# Altium schematic sheet 07-PERIPHERIQUES.SchDoc(07-PERIPHERIQUES)
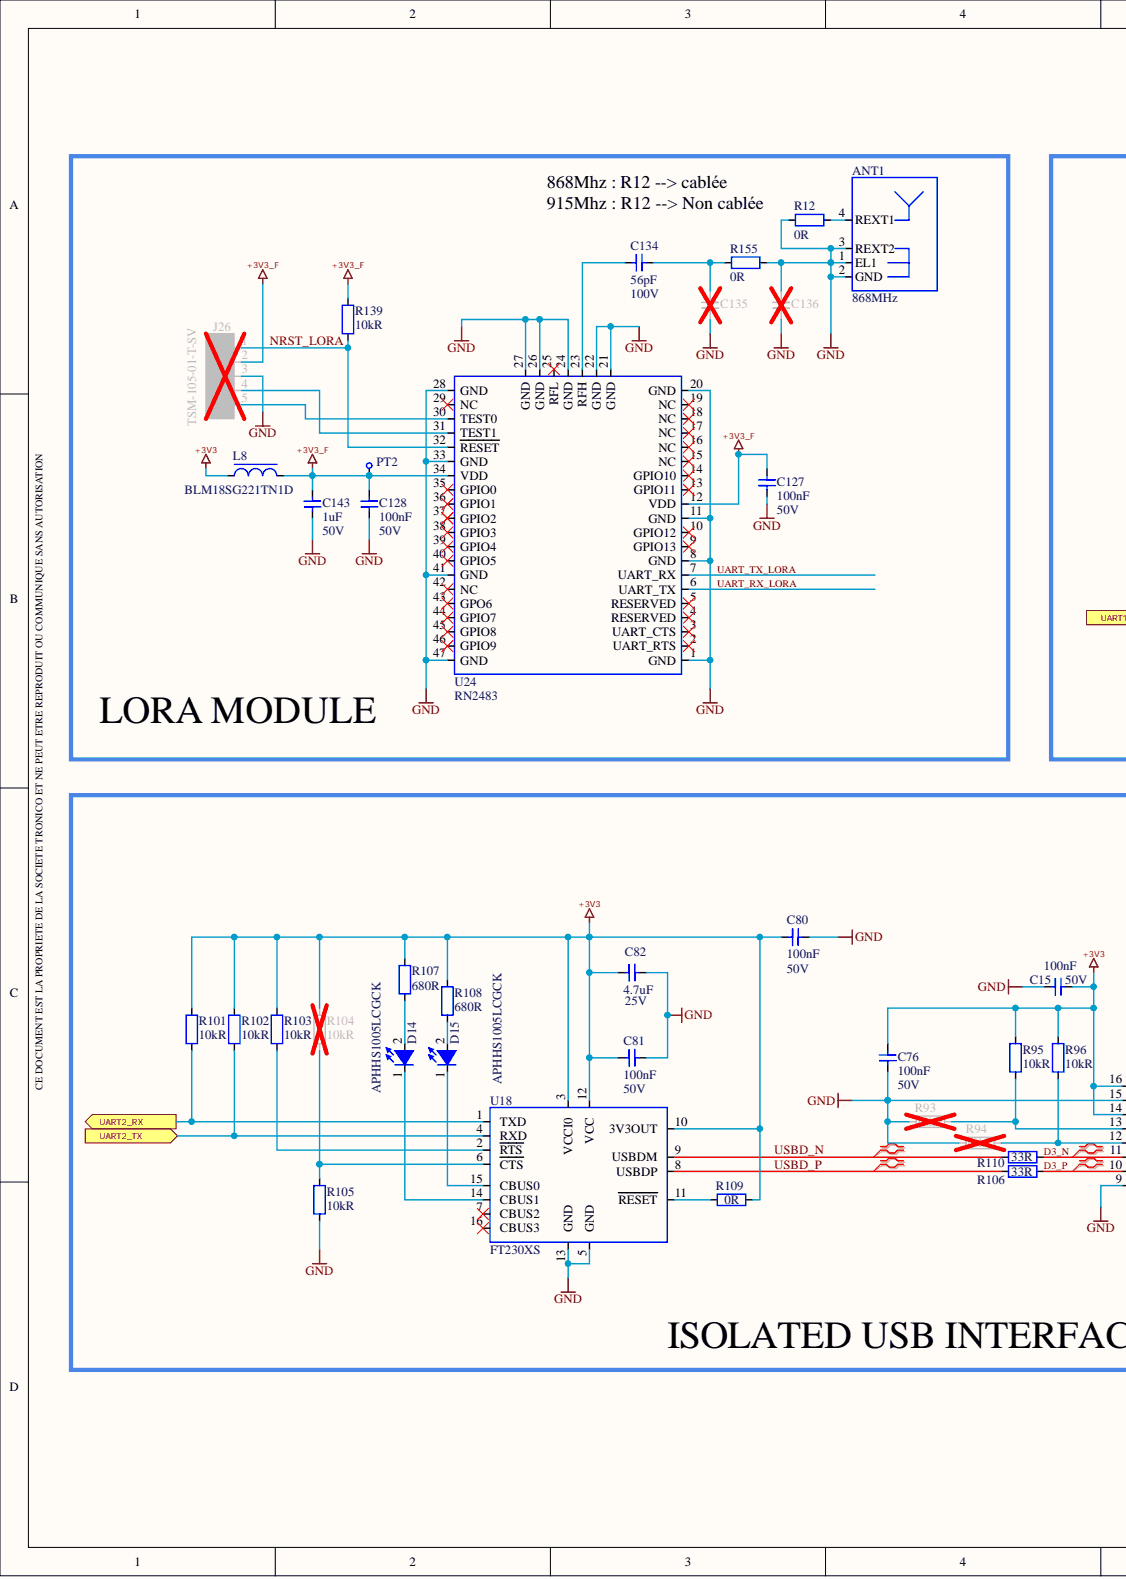
[diagram p0: 07-PERIPHERIQUES.SchDoc, left]
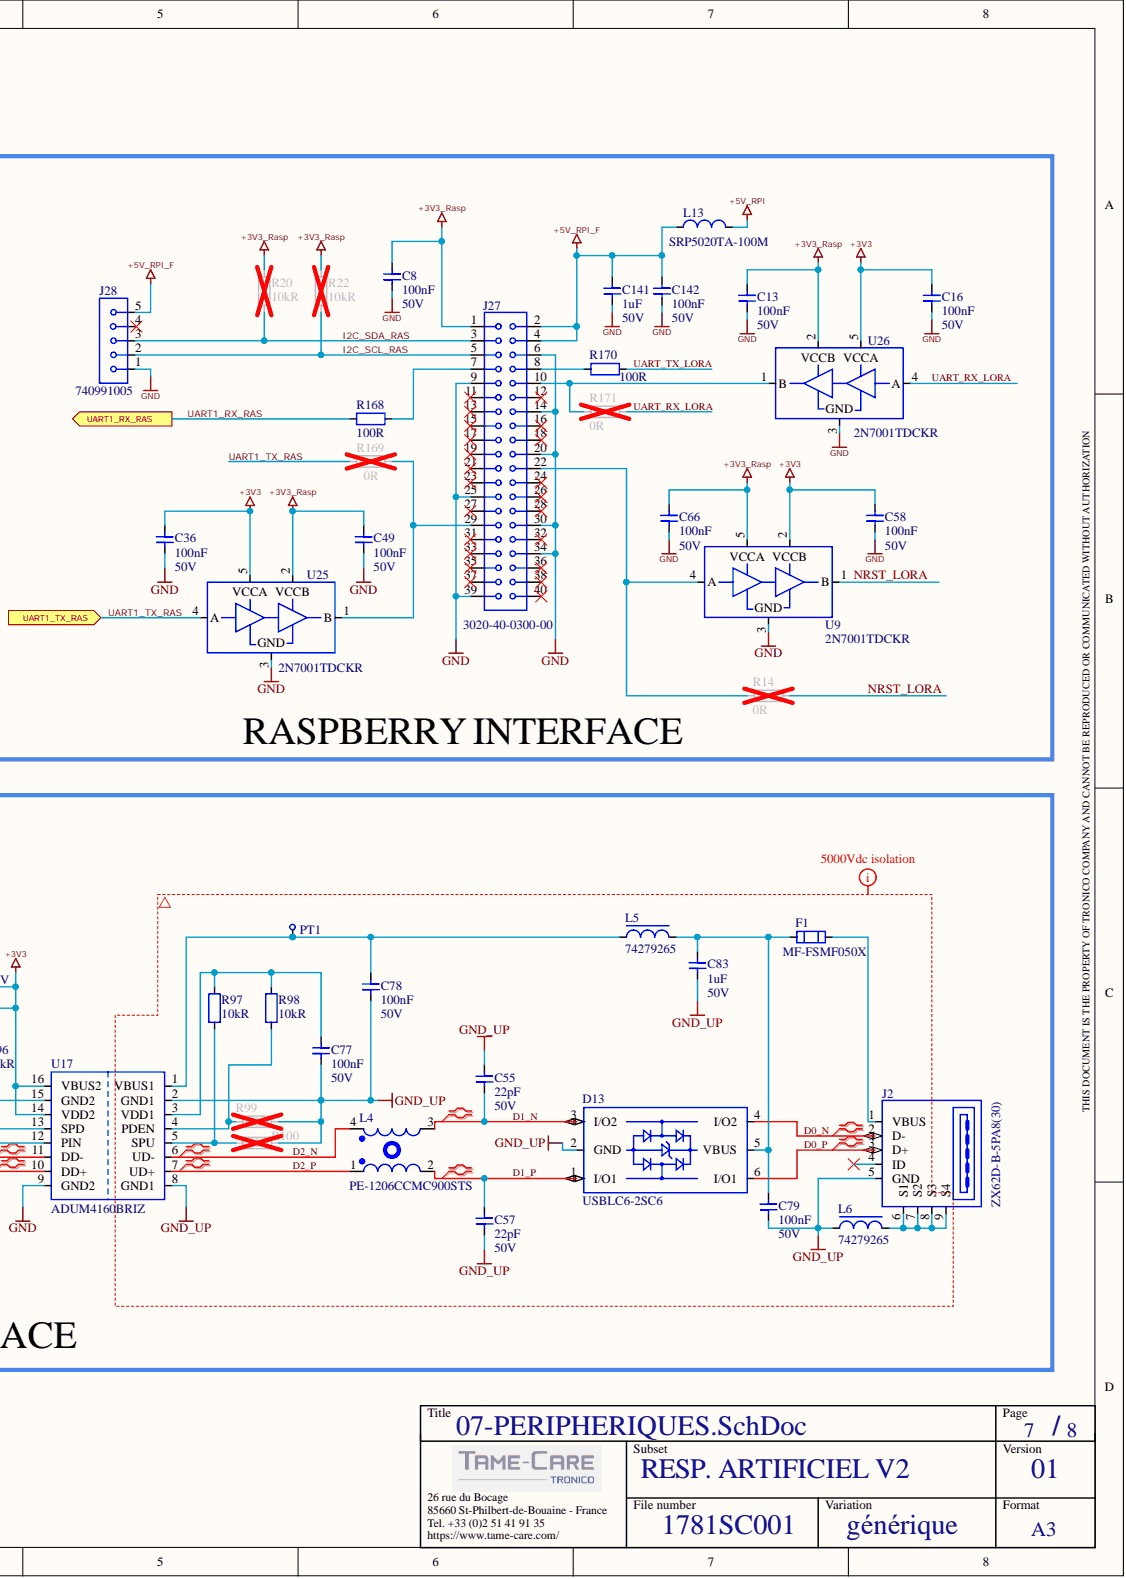
[diagram p1: 07-PERIPHERIQUES.SchDoc, right]

## Parts
ANT1  868MHz  [ANT_LoRa_SX217x_LSDR4RF_V02A]  (no BOM)
C8  100nF  Parameter 1: 50V  [CAPC_0603X094_T]
C13  100nF  Parameter 1: 50V  [CAPC_0603X094_T]
C15  100nF  Parameter 1: 50V  [CAPC_0603X094_T]
C16  100nF  Parameter 1: 50V  [CAPC_0603X094_T]
C36  100nF  [CAPC_0603X094_T]
C49  100nF  Parameter 1: 50V  [CAPC_0603X094_T]
C55  22pF  Parameter 1: 50V  [CAPC_0603X090_T]
C57  22pF  Parameter 1: 50V  [CAPC_0603X090_T]
C58  100nF  Parameter 1: 50V  [CAPC_0603X094_T]
C66  100nF  [CAPC_0603X094_T]
C76  100nF  Parameter 1: 50V  [CAPC_0603X094_T]
C77  100nF  Parameter 1: 50V  [CAPC_0603X094_T]
C78  100nF  Parameter 1: 50V  [CAPC_0603X094_T]
C79  100nF  Parameter 1: 50V  [CAPC_0603X094_T]
C80  100nF  Parameter 1: 50V  [CAPC_0603X094_T]
C81  100nF  [CAPC_0603X094_T]
C82  4.7uF  CHAMP6: 25V  [CAPC_0603X080_T]
C83  1uF  Parameter 1: 50V  [CAPC_0603X080_T]
C127  100nF  Parameter 1: 50V  [CAPC_0603X094_T]
C128  100nF  Parameter 1: 50V  [CAPC_0603X094_T]
C134  56pF  Parameter 1: 100V  [CAPC_0402X050_T]
C135  27pF  [CAPC_0402X050_T]  (value not drawn)
C136  27pF  [CAPC_0402X050_T]  (value not drawn)
C141  1uF  Parameter 1: 50V  [CAPC_0603X080_T]
C142  100nF  Parameter 1: 50V  [CAPC_0603X094_T]
C143  1uF  Parameter 1: 50V  [CAPC_0603X080_T]
D13  USBLC6-2SC6  [SOT23-6_1.6X2.9_1.45_B]
D14  APHHS1005LCGCK  [LEDC_0402x050_GREEN_B]
D15  APHHS1005LCGCK  [LEDC_0402x050_GREEN_B]
F1  MF-FSMF050X  [MF-FSMF050X]
J2  ZX62D-B-5PA8(30)  [CNT_HIROSE_ZX62D_B_5P8_B]
J26  TSM-105-01-T-SV  [CNT_SAMTEC_TSM-105-01-X-SV_B]
J27  3020-40-0300-00  [CNT_CNC_3020-40-0300-00_B]
J28  740991005  [CNT_MOLEX_74099-1005_B]
L4  PE-1206CCMC900STS  [INDC_CCMC-1206_B]
L5  74279265  [IND_0603X080_T]
L6  74279265  [IND_0603X080_T]
L8  BLM18SG221TN1D  [IND_0603X094_T]
L13  SRP5020TA-100M  [INDC_SRP5020T_B]
PT1  PTC3  [PT_0.8-1.5MM]  (value not drawn)  (no BOM)
PT2  PT_0.4  [PT_0.4-1.0mm]  (value not drawn)  (no BOM)
R12  0R  [RESC_0603X045_T]
R14  0R  [RESC_0603X045_T]
R20  10kR  [RESC_0603X045_T]
R22  10kR  [RESC_0603X045_T]
R93  10kR  [RESC_0603X045_T]
R94  10kR  [RESC_0603X045_T]
R95  10kR  [RESC_0603X045_T]
R96  10kR  [RESC_0603X045_T]
R97  10kR  [RESC_0603X045_T]
R98  10kR  [RESC_0603X045_T]
R99  10kR  [RESC_0603X045_T]
R100  10kR  [RESC_0603X045_T]
R101  10kR  [RESC_0603X045_T]
R102  10kR  [RESC_0603X045_T]
R103  10kR  [RESC_0603X045_T]
R104  10kR  [RESC_0603X045_T]
R105  10kR  [RESC_0603X045_T]
R106  33R  [RESC_0603X045_T]
R107  680R  [RESC_0603X045_T]
R108  680R  [RESC_0603X045_T]
R109  0R  [RESC_0603X045_T]
R110  33R  [RESC_0603X045_T]
R139  10kR  [RESC_0603X045_T]
R155  0R  [RESC_0402X035_T]
R168  100R  [RESC_0603X045_T]
R169  0R  [RESC_0603X045_T]
R170  100R  [RESC_0603X045_T]
R171  0R  [RESC_0603X045_T]
U9  2N7001TDCKR  [SC70-5_B]
U17  ADUM4160BRIZ  [SO16W_1.27_12.85X7.6X2.64_B]
U18  FT230XS  [SSOP16_0.635_3.9X4.9X1.8_B]
U24  RN2483  [MICROCHIP_LORA_RN2483_B]
U25  2N7001TDCKR  [SC70-5_B]
U26  2N7001TDCKR  [SC70-5_B]

## Ports
UART1_RX_RAS, UART1_TX_RAS, UART2_RX, UART2_TX

## Nets
+3V3: C15.2 C16.2 C36.2 C58.2 C76.2 C80.1 C81.1 C82.2 L8.1 R95.2 R96.2 R101.1 R102.1 R103.1 R104.1 R107.1 R108.1 R109.1 U9.2 U17.14(VDD2) U17.16(VBUS2) U18.3(VCCI0) U18.10(3V3OUT) U18.12(VCC) U25.5 U26.5
+3V3_F: C127.2 C128.2 C143.2 J26.2 L8.2 PT2.1 R139.1 U24.12(VDD) U24.34(VDD)
+3V3_Rasp: C8.2 C13.2 C49.2 C66.2 J27.1 R20.1 R22.1 U9.5 U25.2 U26.2
+5V_RPI: L13.2
+5V_RPI_F: C141.2 C142.2 J27.2 J27.4 J28.5 L13.1
GND: ANT1.1(EL1) ANT1.2(GND) ANT1.3(REXT2) C8.1 C13.1 C15.1 C16.1 C36.1 C49.1 C58.1 C66.1 C76.1 C80.2 C81.2 C82.1 C127.1 C128.1 C135.1 C136.1 C136.2 C141.1 C142.1 C143.1 J26.3 J27.6 J27.9 J27.14 J27.20 J27.25 J27.30 J27.34 J27.39 J28.1 R12.1 R93.2 R94.2 R105.2 R155.1 U9.3 U17.9(GND2) U17.15(GND2) U18.5(GND) U18.13(GND) U24.1(GND) U24.8(GND) U24.11(GND) U24.20(GND) U24.21(GND) U24.22(GND) U24.24(GND) U24.26(GND) U24.27(GND) U24.28(GND) U24.33(GND) U24.41(GND) U24.47(GND) U25.3 U26.3
GND_UP: C55.2 C57.1 C77.1 C78.1 C79.1 C83.1 D13.2(GND) J2.5(GND) L6.1 R99.1 R100.1 U17.2(GND1) U17.8(GND1)
D0_N: D13.4(I/O2) J2.2(D-)
D0_P: D13.6(I/O1) J2.3(D+)
D1_N: C55.1 D13.3(I/O2) L4.3
D1_P: C57.2 D13.1(I/O1) L4.2
D2_N: L4.4 U17.6(UD-)
D2_P: L4.1 U17.7(UD+)
D3_N: R110.1 U17.11(DD-)
D3_P: R106.1 U17.10(DD+)
I2C_SCL_RAS: J27.5 J28.2 R22.2
I2C_SDA_RAS: J27.3 J28.3 R20.2
NRST_LORA: J26.1 R14.1 R139.2 U9.1(B) U24.32(RESET)
UART1_RX_RAS: R168.2 ; port UART1_RX_RAS
UART1_TX_RAS: R169.2 U25.4(A) ; port UART1_TX_RAS
UART2_RX: R101.2 U18.1(TXD) ; port UART2_RX
UART2_TX: R102.2 U18.4(RXD) ; port UART2_TX
UART_RX_LORA: R171.1 U24.6(UART_TX) U26.4(A)
UART_TX_LORA: R170.1 U24.7(UART_RX)
USBD_N: R110.2 U18.9(USBDM)
USBD_P: R106.2 U18.8(USBDP)
NetANT1_4: ANT1.4(REXT1) R12.2
NetC77_2: C77.2 R97.1 R98.1 U17.3(VDD1)
NetC78_2: C78.2 L5.1 PT1.1 U17.1(VBUS1)
NetC79_2: C79.2 C83.2 D13.5(VBUS) F1.2 L5.2
NetC134_1: C134.1 U24.23(RFH)
NetC134_2: C134.2 C135.2 R155.2
NetD14_1: D14.1 U18.14(CBUS1)
NetD14_2: D14.2 R107.2
NetD15_1: D15.1 U18.15(CBUS0)
NetD15_2: D15.2 R108.2
NetF1_1: F1.1 J2.1(VBUS)
NetJ2_6: J2.6(S1) J2.7(S2) J2.8(S3) J2.9(S4) L6.2
NetJ26_4: J26.4 U24.31(TEST1)
NetJ26_5: J26.5 U24.30(TEST0)
NetJ27_7: J27.7 R168.1
NetJ27_8: J27.8 R170.2
NetJ27_10: J27.10 R171.2 U26.1(B)
NetJ27_22: J27.22 R14.2 U9.4(A)
NetJ27_29: J27.29 R169.1 U25.1(B)
NetR93_1: R93.1 R95.1 U17.13(SPD)
NetR94_1: R94.1 R96.1 U17.12(PIN)
NetR97_2: R97.2 R100.2 U17.5(SPU)
NetR98_2: R98.2 R99.2 U17.4(PDEN)
NetR103_2: R103.2 U18.2(RTS)
NetR104_2: R104.2 R105.1 U18.6(CTS)
NetR109_2: R109.2 U18.11(RESET)
N00341:

Not connected: J2 4(ID); J27 11 12 13 15 16 17 18 19 21 23 24 26 27 28 31 32 33 35 36 37 38 40; J28 4; U18 7(CBUS2) 16(CBUS3); U24 2(UART_RTS) 3(UART_CTS) 4(RESERVED) 5(RESERVED) 9(GPIO13) 10(GPIO12) 13(GPIO11) 14(GPIO10) 15(NC) 16(NC) 17(NC) 18(NC) 19(NC) 25(RFL) 29(NC) 35(GPIO0) 36(GPIO1) 37(GPIO2) 38(GPIO3) 39(GPIO4) 40(GPIO5) 42(NC) 43(GPO6) 44(GPIO7) 45(GPIO8) 46(GPIO9)

## Library data of the parts
ANT1 = 4BU00008: ANTENNE PCB 16x50mm 868MHz; REV_NOTE: MaJ Champs Librairies; REV_AUTHOR: llannuzel; REF_FAB: LORA_SX127x_LSDR4RF_V01A; QUALIF: None; LEVEL: 1; FAMILLE: INDUCTIFS; FAB: TRONICO; Database Table Name: v_bt_all; SS_FAMILLE: ANTENNE; Simulation: INDUCTOR; Signal Integrity: Antenne; REV_DATE: 02/05/2020 23:18:44; ID_RnD: 4BU00008; HelpURL: http://bt_rnd/ds/04_inductif/SEMTECH/SX127x_LSDR4RF_V01A.pdf; CHAMP5: 868MHz; CHAMP2: 16x50mm; CHAMP1: PCB; ACTIF: ACTIF; Library Name: SVNDatabase_BaseTechnique.SVNDbLib
C8 C13 C15 C16 C36 C49 C58 C66 C76-C81 C127 C128 C142 = 23U00036: COND_CERAM CMS 0603 X7R 100nF 50V 10%; REV_NOTE: Maj Champs Techniques; REV_AUTHOR: nlelievre; REF_FAB: 06035C104KAT2A; LEVEL: 1; FAMILLE: CAPACITIFS; FAB: AVX; Database Table Name: v_bt_all; CHAMP9: -55°C/+125°C; CHAMP2: 0603; SS_FAMILLE: COND_CERAM; Simulation: CAP; Signal Integrity: Cap; REV_DATE: 16/10/2019 13:20:57; Library Name: SVNDatabase_BaseTechnique.SVNDbLib; ID_RnD: 23U00036; HelpURL: http://Bt_rnd/ds/02_capacitif/AVX/AVX_cx7r.pdf; ComponentLink2Description: Manufacturer Website; ComponentLink1Description: Manufacturer Datasheet; Component Kind: Standard; CHAMP7: 10%; CHAMP6: 50V; CHAMP5: 100nF; CHAMP3: X7R; CHAMP1: CMS; ACTIF: ACTIF
  C36 C66 C81: Parameter 1: 50V
C55 C57 = 23U00046: COND_CERAM CMS 0603 C0G 22pF 50V 10%; REV_NOTE: MaJ Champs Techniques; REV_AUTHOR: lclavier; REF_FAB: C0603C220K5GACTU; LEVEL: 1; FAMILLE: CAPACITIFS; FAB: KEMET; Database Table Name: v_bt_all; CHAMP9: -55°C/+125°C; CHAMP2: 0603; SS_FAMILLE: COND_CERAM; Simulation: CAP; Signal Integrity: Cap; REV_DATE: 02/05/2020 13:19:47; Library Name: SVNDatabase_BaseTechnique.SVNDbLib; ID_RnD: 23U00046; HelpURL: http://Bt_rnd/ds/02_capacitif/AVX/AVX_cx7r.pdf; ComponentLink3URL: None; ComponentLink3Description: Qualification information; ComponentLink2URL: http://www.avx.com; ComponentLink2Description: Manufacturer Website; ComponentLink1URL: http://www.avx.com/docs/Catalogs/cx7r.pdf; ComponentLink1Description: Manufacturer Datasheet; Component Kind: Standard; CHAMP7: 10%; CHAMP6: 50V; CHAMP5: 22pF; CHAMP3: C0G; CHAMP1: CMS; ACTIF: ACTIF
C82 = 23U00282: COND_CERAM CMS 0603 X5R  4.7uF 25V 10%; Valide / Non Valide: OK; REV_NOTE: MaJ Champs Librairies; REV_AUTHOR: llannuzel; REF_FAB: GRM188R61E475KE15D; QUALIF: None; LEVEL: 1; FAMILLE: CAPACITIFS; FAB: MURATA; Database Table Name: v_bt_all; CHAMP9: -55°C/+85°C; CHAMP2: 0603; SS_FAMILLE: COND_CERAM; Simulation: CAP; Signal Integrity: Cap; REV_DATE: 28/05/2018 09:39:46; ID_RnD: 23U00282; HelpURL: http://bt_rnd/ds/02_capacitif/murata/GRM18_series.pdf; ComponentLink2Description: Manufacturer Website; ComponentLink1Description: Manufacturer Datasheet; Component Kind: Standard; CHAMP7: 10%; CHAMP5: 4.7uF; CHAMP3: X5R; CHAMP1: CMS; ACTIF: ACTIF; Library Name: SVNDatabase_BaseTechnique.SVNDbLib
C83 C141 C143 = 23U00347: COND_CERAM CMS 0603 X5R 1uF 50V 10%; REV_NOTE: Maj Champs Techniques; REV_AUTHOR: nlelievre; REF_FAB: CGA3E3X5R1H105K080AB; LEVEL: 1; FAMILLE: CAPACITIFS; FAB: TDK; Database Table Name: v_bt_all; CHAMP9: -55°C/+85°C; CHAMP2: 0603; SS_FAMILLE: COND_CERAM; Simulation: CAP; Signal Integrity: Cap; REV_DATE: 16/10/2019 13:35:17; Library Name: SVNDatabase_BaseTechnique.SVNDbLib; ID_RnD: 23U00347; HelpURL: http://bt_rnd/ds/02_capacitif/TDK/mlccspec_automotive_general_midvoltage_en.pdf; ComponentLink2Description: Manufacturer Website; ComponentLink1Description: Manufacturer Datasheet; Component Kind: Standard; CHAMP7: 10%; CHAMP6: 50V; CHAMP5: 1uF; CHAMP3: X5R; CHAMP1: CMS; ACTIF: ACTIF
C134 = 23U00494: COND_CERAM CMS 0402 COG HF 56pF 100V 1%; REV_NOTE: Migration vers t_bt; REV_AUTHOR: lclavier; REF_FAB: CBR04C560F1GAC; LEVEL: 1; FAMILLE: CAPACITIFS; FAB: KEMET; Database Table Name: v_bt_all; CHAMP9: -55°C/+125°C; CHAMP2: 0402; SS_FAMILLE: COND_CERAM; Simulation: CAP; Signal Integrity: Cap; REV_DATE: 07/05/2020 11:53:31; ID_RnD: 23U00494; HelpURL: http://bt_rnd/ds/02_capacitif/KEMET/KEM_C1030_CBR_SMD.pdf; ComponentLink2Description: Manufacturer Website; ComponentLink1Description: Manufacturer Datasheet; Component Kind: Standard; CHAMP7: 1%; CHAMP6: 100V; CHAMP5: 56pF; CHAMP4: HF; CHAMP3: COG; CHAMP1: CMS; ACTIF: ACTIF; Library Name: SVNDatabase_BaseTechnique.SVNDbLib
C135 C136 = 23U00333: COND_CERAM CMS 0402 C0G 27pF 50V 1%; REV_NOTE: Maj Champs Techniques; REV_AUTHOR: nlelievre; REF_FAB: GCM1555C1H270FA16D; LEVEL: 1; FAMILLE: CAPACITIFS; FAB: MURATA; Database Table Name: v_bt_all; CHAMP9: -55°C/+125°C; CHAMP2: 0402; SS_FAMILLE: COND_CERAM; Simulation: CAP; Signal Integrity: Cap; REV_DATE: 16/10/2019 13:35:03; Parameter 1: 50V; Library Name: SVNDatabase_BaseTechnique.SVNDbLib; ID_RnD: 23U00333; HelpURL: http://BT_RND/ds/02_capacitif/murata/GCM1555C1H270FA16.pdf; ComponentLink2Description: Manufacturer Website; ComponentLink1URL: https://psearch.en.murata.com/capacitor/product/GCM1555C1H270FA16%23.html; ComponentLink1Description: Manufacturer Website; Component Kind: Standard; CHAMP7: 1%; CHAMP6: 50V; CHAMP5: 27pF; CHAMP3: C0G; CHAMP1: CMS; ACTIF: ACTIF
D13 = 38U00032: DIODE_ESD CMS SOT23-6L UNI 15kV  DUAL -40°C/+125°C -55°C/+150°C; REV_NOTE: MàJ footprint_path et footprint_ref; REV_AUTHOR: llannuzel; QUALIF: None; LEVEL: 1; FAMILLE: DIODES; FAB: ST MICROELECTRONICS; Database Table Name: v_bt_all; SS_FAMILLE: DIODE_ESD; REV_DATE: 13/12/2019 10:05:20; REF_FAB: USBLC6-2SC6; ID_RnD: 38U00032; HelpURL: http://bt_rnd/ds/03_diode/st/USBLC6_2.pdf; ComponentLink2Description: Manufacturer Website; ComponentLink1URL: https://www.st.com/content/ccc/resource/technical/document/datasheet/06/1d/48/9c/6c/20/4a/b2/CD00050750.pdf/files/CD00050750.pdf/jcr:content/translations/en.CD00050750.pdf; ComponentLink1Description: Manufacturer Datasheet; Component Kind: Standard; CHAMP10: -55°C/+150°C; CHAMP9: -40°C/+125°C; CHAMP8: DUAL; CHAMP5: 15kV; CHAMP4: UNI; CHAMP2: SOT23-6L; CHAMP1: CMS; ACTIF: ACTIF; Library Name: SVNDatabase_BaseTechnique.SVNDbLib
D14 D15 = E1U00022: DIODE_LED VERTE CMS 0402 574nm 3.5mcd 1.9V; REV_NOTE: MaJ Champs URL; REV_AUTHOR: lclavier; QUALIF: None; LEVEL: 1; FAMILLE: OPTOELECTRONIQUE; FAB: KINGBRIGHT; Database Table Name: v_bt_all; CHAMP10: -40°C/+85°C; CHAMP8: 2mA; CHAMP4: 0402; [ Valide ]: OK; SS_FAMILLE: DIODE_LED; REV_DATE: 15/02/2019 16:02:32; REF_FAB: APHHS1005LCGCK; ID_RnD: E1U00022; HelpURL: http://bt_rnd/ds/15_opto/kingbright/APHHS1005LCGCK.pdf; ComponentLink2Description: Manufacturer Website; ComponentLink1Description: Manufacturer Datasheet; Component Kind: Standard; CHAMP9: -40°C/+85°C; CHAMP7: 1.9V; CHAMP6: 3.5mcd; CHAMP5: 574nm; CHAMP3: CMS; CHAMP1: VERTE; ACTIF: ACTIF; Library Name: SVNDatabase_BaseTechnique.SVNDbLib
F1 = 86U00021: FUSIBLE REARMABLE CMS 0603   500mA 6V; Valide / Non Valide: OK; REV_NOTE: Migration vers t_bt; REV_AUTHOR: JRENVOISE; QUALIF: None; LEVEL: 1; FAMILLE: COMMUTATION; FAB: BOURNS; Database Table Name: v_bt_all; CHAMP9: -40°C/+85°C; CHAMP8: THERM; CHAMP3: 0603; SS_FAMILLE: FUSIBLE; REV_DATE: 02/05/2017 13:02:38; REF_FAB: MF-FSMF050X; ID_RnD: 86U00021; HelpURL: //bt_rnd/ds/08_commutation/Bourns/MF_FSMF-serie.pdf; ComponentLink2Description: Manufacturer Website; ComponentLink1Description: Manufacturer Datasheet; Component Kind: Standard; CHAMP7: 6V; CHAMP6: 500mA; CHAMP2: CMS; CHAMP1: REARMABLE; ACTIF: ACTIF; Library Name: SVNDatabase_BaseTechnique.SVNDbLib
J2 = A7U00249: CONNECT USB CMS 5PTS EMBASE EMBASE RECT FEM COUDE -30°C/+85°C; REV_NOTE: MaJ Champs Techniques; REV_AUTHOR: lclavier; QUALIF: None; LEVEL: 1; FAMILLE: CONNECTIQUE; FAB: HIROSE; Database Table Name: v_bt_all; SS_FAMILLE: CONNECT; REV_DATE: 25/02/2019 13:48:49; REF_FAB: ZX62D-B-5PA8(30); Library Name: SVNDatabase_BaseTechnique.SVNDbLib; ID_RnD: A7U00249; HelpURL: http://bt_rnd/ds/10_connectique/hirose/ZX_Series.pdf; ComponentLink2Description: Manufacturer Website; ComponentLink1URL: https://www.hirose.com/product/en/products/ZX/ZX62D-B-5PA8(30)/; ComponentLink1Description: Manufacturer Datasheet; Component Kind: Standard; CHAMP9: -30°C/+85°C; CHAMP8: COUDE; CHAMP7: FEM; CHAMP6: RECT; CHAMP5: EMBASE; CHAMP4: 5PTS; CHAMP3: CMS; CHAMP1: USB; ACTIF: ACTIF
J26 = A7U00320: CONNECT TSM CMS 5PTS EMBASE RECT MALE DROIT; Valide: OK; REV_NOTE: Migration vers t_bt; REV_AUTHOR: lclavier; LEVEL: 1; FAMILLE: CONNECTIQUE; FAB: SAMTEC; Database Table Name: v_bt_all; CHAMP9: -55°C/+105°C; SS_FAMILLE: CONNECT; REV_DATE: 24/04/2020 18:20:39; REF_FAB: TSM-105-01-T-SV; ID_RnD: A7U00320; HelpURL: http://bt_rnd/ds/10_connectique/SAMTEC/tsm-1xx-xx-xxx-sv-xx-xxx-xx-mkt.pdf; ComponentLink3URL: None; ComponentLink3Description: None; ComponentLink2URL: None; ComponentLink2Description: Manufacturer Website; ComponentLink1URL: http://bt_rnd/ds/10_connectique/SAMTEC/tsm-1xx-xx-xxx-sv-xx-xxx-xx-mkt.pdf; ComponentLink1Description: Footprint; Component Kind: Standard; CHAMP8: DROIT; CHAMP7: MALE; CHAMP6: RECT; CHAMP5: EMBASE; CHAMP4: 5PTS; CHAMP3: CMS; CHAMP1: TSM; ACTIF: ACTIF; Library Name: SVNDatabase_BaseTechnique.SVNDbLib
J27 = A7U00330: CONNECT CMS 2x20PTS EMBASE RECT MALE DROIT; REV_NOTE: Migration vers t_bt; REV_AUTHOR: llannuzel; LEVEL: 1; FAMILLE: CONNECTIQUE; FAB: CNC TECH; Database Table Name: v_bt_all; CHAMP9: -40°C/+105°C; SS_FAMILLE: CONNECT; REV_DATE: 06/05/2020 15:56:42; REF_FAB: 3020-40-0300-00; ID_RnD: A7U00330; HelpURL: http://bt_rnd/ds/10_connectique/CNC/3020-XX-0300-00-TR.pdf; ComponentLink2Description: Manufacturer Website; ComponentLink1Description: Manufacturer Datasheet; Component Kind: Standard; CHAMP8: DROIT; CHAMP7: MALE; CHAMP6: RECT; CHAMP5: EMBASE; CHAMP4: 2x20PTS; CHAMP3: CMS; ACTIF: ACTIF; Library Name: SVNDatabase_BaseTechnique.SVNDbLib
J28 = A7U00327: CONNECT CMS 5PTS EMBASE RECT MALE DROIT; Valide: OK; REV_NOTE: Migration vers t_bt; REV_AUTHOR: llannuzel; LEVEL: 1; FAMILLE: CONNECTIQUE; FAB: MOLEX; Database Table Name: v_bt_all; CHAMP9: -40°C/+105°C; SS_FAMILLE: CONNECT; REV_DATE: 05/05/2020 00:31:09; REF_FAB: 740991005; ID_RnD: A7U00327; HelpURL: http://bt_rnd/ds/10_connectique/MOLEX/740990013_sd.pdf; ComponentLink2Description: Manufacturer Website; ComponentLink1Description: Manufacturer Datasheet; Component Kind: Standard; CHAMP8: DROIT; CHAMP7: MALE; CHAMP6: RECT; CHAMP5: EMBASE; CHAMP4: 5PTS; CHAMP3: CMS; ACTIF: ACTIF; Library Name: SVNDatabase_BaseTechnique.SVNDbLib
L4 = 48U00023: SELF_MODE_COMMUN CMS 1206TS  90R 25% 370mA; REV_NOTE: Migration vers t_bt; REV_AUTHOR: jrenvoise; LEVEL: 1; FAMILLE: INDUCTIFS; FAB: PULSE; Database Table Name: v_bt_all; CHAMP9: -40°C/+125°C; CHAMP8: 50V; SS_FAMILLE: SELF_MODE_COMMUN; REV_DATE: 22/01/2019 09:04:07; REF_FAB: PE-1206CCMC900STS; ID_RnD: 48U00023; HelpURL: http://bt_rnd/ds/04_inductif/Pulse/CCMC_Series.pdf; ComponentLink2Description: Manufacturer Website; ComponentLink1Description: Manufacturer Datasheet; Component Kind: Standard; CHAMP7: 370mA; CHAMP6: 25%; CHAMP5: 90R; CHAMP2: 1206TS; CHAMP1: CMS; ACTIF: ACTIF; Library Name: SVNDatabase_BaseTechnique.SVNDbLib
L5 L6 = 43U00020: FERRITES CMS 0603  600R 100MHz 200mA -55°C/+125°C -20°C/+60°C; Valide / Non Valide: OK; REV_NOTE: MaJ Champs Techniques; REV_AUTHOR: llannuzel; QUALIF: None; LEVEL: 1; FAMILLE: INDUCTIFS; FAB: WE; Database Table Name: v_bt_all; CHAMP2: 0603; SS_FAMILLE: FERRITES; Simulation: INDUCTOR; Signal Integrity: Inductor; REV_DATE: 12/12/2018 09:06:22; REF_FAB: 74279265; Library Name: SVNDatabase_BaseTechnique.SVNDbLib; ID_RnD: 43U00020; HelpURL: http://katalog.we-online.de/en/pbs/WE-CBF/S/74279265?m=n&sp=https%3A%2F%2Fwww.we-online.com%2Fweb%2Fen%2Fwuerth_elektronik%2Fsearchpage.php%3Fsearch%3D74279265&_ga=1.202762072.896363361.1456824674; ComponentLink3Description: Qualif+T22:AF24ication information; ComponentLink2URL: https://www.we-online.com/web/en/wuerth_elektronik/start.php; ComponentLink2Description: Manufacturer Website; ComponentLink1URL: http://katalog.we-online.de/pbs/datasheet/74279265.pdf; ComponentLink1Description: Manufacturer Datasheet; Component Kind: Standard; CHAMP10: -20°C/+60°C; CHAMP9: -55°C/+125°C; CHAMP7: 200mA; CHAMP6: 100MHz; CHAMP5: 600R; CHAMP1: CMS; ACTIF: ACTIF
L8 = 43U00016: FERRITES CMS 0603  220R 100MHz 2.5A -55°C/+125°C -55°C/+125°C; Valide / Non Valide: OK; REV_NOTE: Maj Library Ref & Library Path; REV_AUTHOR: jcaille; LEVEL: 1; FAMILLE: INDUCTIFS; FAB: MURATA; Database Table Name: v_bt_all; CHAMP2: 0603; SS_FAMILLE: FERRITES; Simulation: INDUCTOR; Signal Integrity: Inductor; REV_DATE: 10/12/2019 16:11:10; REF_FAB: BLM18SG221TN1D; ID_RnD: 43U00016; HelpURL: http://bt_rnd/ds/04_inductif/MURATA/MURATA_5151.pdf; ComponentLink2Description: Manufacturer Website; ComponentLink1Description: Manufacturer Datasheet; Component Kind: Standard; CHAMP10: -55°C/+125°C; CHAMP9: -55°C/+125°C; CHAMP7: 2.5A; CHAMP6: 100MHz; CHAMP5: 220R; CHAMP1: CMS; ACTIF: ACTIF; Library Name: SVNDatabase_BaseTechnique.SVNDbLib
L13 = 42U00140: SELF CMS 5.2x5.7x1.8mm 10µH 20% 3.4A; REV_NOTE: MaJ Champs Librairies; REV_AUTHOR: lclavier; LEVEL: 1; FAMILLE: INDUCTIFS; FAB: BOURNS; Database Table Name: v_bt_all; CHAMP10: -40°C/+150°C; SS_FAMILLE: SELF; Simulation: INDUCTOR; Signal Integrity: Inductor; REV_DATE: 21/10/2019 17:00:03; REF_FAB: SRP5020TA-100M; ID_RnD: 42U00140; HelpURL: http://bt_rnd/ds/04_inductif/BOURNS/srp5020ta.pdf; ComponentLink2Description: Manufacturer Website; ComponentLink1Description: Manufacturer Datasheet; Component Kind: Standard; CHAMP9: -40°C/+150°C; CHAMP7: 3.4A; CHAMP6: 20%; CHAMP5: 10µH; CHAMP2: 5.2x5.7x1.8mm; CHAMP1: CMS; ACTIF: ACTIF; Library Name: SVNDatabase_BaseTechnique.SVNDbLib
PT1 = H6U00003: POINT_TEST; REV_NOTE: Migration vers t_bt; REV_AUTHOR: jrenvoise; LEVEL: 1; FAMILLE: DIVERS; FAB: TRONICO; Database Table Name: v_bt_all; SS_FAMILLE: POINT_TEST; REV_DATE: 24/04/2017 12:22:28; REF_FAB: PTC3; ID_RnD: H6U00003; ACTIF: ACTIF; Library Name: SVNDatabase_BaseTechnique.SVNDbLib
PT2 = H6U00006: SS_FAMILLE: POINT_TEST; REV_NOTE: Migration vers t_bt; REV_AUTHOR: llannuzel; LEVEL: 1; FAMILLE: DIVERS; FAB: TRONICO; Database Table Name: v_bt_all; CHAMP1: PASTILLE; REV_DATE: 01/05/2020 18:33:20; REF_FAB: PT_0.4; ID_RnD: H6U00006; HelpURL: http://bt_rnd/ds/; ComponentLink2Description: Manufacturer Website; ComponentLink1Description: Manufacturer Datasheet; ACTIF: ACTIF; Library Name: SVNDatabase_BaseTechnique.SVNDbLib
R12 R14 R109 R169 R171 = 11U00009: REST CMS 0603 100PPM  0R 1/10W 20mR -55°C/+155°C; Valide / Non Valide: OK; REV_NOTE: MaJ Champs URL; REV_AUTHOR: jrenvoise; REF_FAB: CRCW06030000Z0EA; LEVEL: 1; FAMILLE: RESISTIFS; FAB: VISHAY; Database Table Name: v_bt_all; CHAMP2: 0603; SS_FAMILLE: REST; Simulation: RESISTOR; Signal Integrity: Res; REV_DATE: 24/10/2018 10:43:14; ID_RnD: 11U00009; HelpURL: http://bt_rnd/ds/01_resistif/vishay/Vishay_D-CRCWE3.pdf; ComponentLink2Description: Manufacturer Website; ComponentLink1URL: http://www.vishay.com/docs/20035/dcrcwe3.pdf; ComponentLink1Description: Manufacturer Datasheet; Component Kind: Standard; CHAMP9: -55°C/+155°C; CHAMP8: 20mR; CHAMP6: 1/10W; CHAMP5: 0R; CHAMP3: 100PPM; CHAMP1: CMS; ACTIF: ACTIF; Library Name: SVNDatabase_BaseTechnique.SVNDbLib
R20 R22 R93-R105 R139 = 11U00108: REST CMS 0603 100PPM 10kR 1/10W 1% -55°C/+155°C; Valide / Non Valide: OK; REV_NOTE: MaJ description; REV_AUTHOR: jrenvoise; REF_FAB: RC0603FR-0710KL; LEVEL: 1; FAMILLE: RESISTIFS; FAB: YAGEO; Database Table Name: v_bt_all; CHAMP2: 0603; SS_FAMILLE: REST; Simulation: RESISTOR; Signal Integrity: Res; REV_DATE: 12/09/2018 10:54:26; ID_RnD: 11U00108; HelpURL: http://bt_rnd/ds/01_resistif/yageo/RC-L_Series.pdf; ComponentLink2Description: Manufacturer Website; ComponentLink1Description: Manufacturer Datasheet; Component Kind: Standard; CHAMP9: -55°C/+155°C; CHAMP7: 1%; CHAMP6: 1/10W; CHAMP5: 10kR; CHAMP3: 100PPM; CHAMP1: CMS; ACTIF: ACTIF; Library Name: SVNDatabase_BaseTechnique.SVNDbLib
R106 R110 = 11U01018: REST CMS 0603 100PPM 33R 1/10W 5% 75V; Valide / Non Valide: OK; REV_NOTE: Migration vers t_bt; REV_AUTHOR: lclavier; REF_FAB: RC0603JR-0733RL; LEVEL: 1; FAMILLE: RESISTIFS; FAB: YAGEO; Database Table Name: v_bt_all; CHAMP9: -55°C/+155°C; CHAMP2: 0603; SS_FAMILLE: REST; Simulation: RESISTOR; Signal Integrity: Res; REV_DATE: 20/04/2020 18:36:50; ID_RnD: 11U01018; HelpURL: http://bt_rnd/ds/01_resistif/yageo/RC-L_Series.pdf; ComponentLink2Description: Manufacturer Website; ComponentLink1Description: Manufacturer Datasheet; Component Kind: Standard; CHAMP8: 75V; CHAMP7: 5%; CHAMP6: 1/10W; CHAMP5: 33R; CHAMP3: 100PPM; CHAMP1: CMS; ACTIF: ACTIF; Library Name: SVNDatabase_BaseTechnique.SVNDbLib
R107 R108 = 11U00072: REST CMS 0603 100PPM  680R 1/10W 1% -55°C/+155°C; Valide / Non Valide: OK; REV_NOTE: MaJ description; REV_AUTHOR: jrenvoise; REF_FAB: RC0603FR-07680RL; LEVEL: 1; FAMILLE: RESISTIFS; FAB: YAGEO; Database Table Name: v_bt_all; CHAMP2: 0603; SS_FAMILLE: REST; Simulation: RESISTOR; Signal Integrity: Res; REV_DATE: 12/09/2018 11:17:00; ID_RnD: 11U00072; HelpURL: http://bt_rnd/ds/01_resistif/YAGEO/RC-L_Series.pdf; ComponentLink2Description: Manufacturer Website; ComponentLink1Description: Manufacturer Datasheet; Component Kind: Standard; CHAMP9: -55°C/+155°C; CHAMP7: 1%; CHAMP6: 1/10W; CHAMP5: 680R; CHAMP3: 100PPM; CHAMP1: CMS; ACTIF: ACTIF; Library Name: SVNDatabase_BaseTechnique.SVNDbLib
R155 = 11U00352: REST CMS 0402 0R 20mR 1.5A -55°C/+155°C; Valide / Non Valide: OK; REV_NOTE: MaJ Champs Techniques; REV_AUTHOR: llannuzel; REF_FAB: CRCW04020000Z0ED; LEVEL: 1; FAMILLE: RESISTIFS; FAB: VISHAY; Database Table Name: v_bt_all; CHAMP2: 0402; SS_FAMILLE: REST; Simulation: RESISTOR; Signal Integrity: Res; REV_DATE: 16/11/2018 14:30:01; Library Name: SVNDatabase_BaseTechnique.SVNDbLib; ID_RnD: 11U00352; HelpURL: http://bt_rnd/ds/01_resistif/vishay/Vishay_D-CRCWE3.pdf; ComponentLink2Description: Manufacturer Website; ComponentLink1Description: Manufacturer Datasheet; Component Kind: Standard; CHAMP9: -55°C/+155°C; CHAMP8: 1.5A; CHAMP7: 20mR; CHAMP5: 0R; CHAMP1: CMS; ACTIF: ACTIF
R168 R170 = 11U00071: REST CMS 0603 100PPM 100R 1/10W 1% -55°C/+155°C; Valide / Non Valide: OK; REV_NOTE: MaJ description; REV_AUTHOR: jrenvoise; REF_FAB: RC0603FR-07100RL; LEVEL: 1; FAMILLE: RESISTIFS; FAB: YAGEO; Database Table Name: v_bt_all; CHAMP2: 0603; SS_FAMILLE: REST; Simulation: RESISTOR; Signal Integrity: Res; REV_DATE: 12/09/2018 10:54:26; ID_RnD: 11U00071; HelpURL: http://bt_rnd/ds/01_resistif/yageo/RC-L_Series.pdf; ComponentLink2Description: Manufacturer Website; ComponentLink1Description: Manufacturer Datasheet; Component Kind: Standard; CHAMP9: -55°C/+155°C; CHAMP7: 1%; CHAMP6: 1/10W; CHAMP5: 100R; CHAMP3: 100PPM; CHAMP1: CMS; ACTIF: ACTIF; Library Name: SVNDatabase_BaseTechnique.SVNDbLib
U9 U25 U26 = 67U00126: CI_DRIVER CMS SC70 BUFFER -0.5V/+4.2V; REV_NOTE: MaJ Champs Techniques; REV_AUTHOR: llannuzel; QUALIF: None; LEVEL: 1; FAMILLE: CIRCUITS_INTEGRES; FAB: TEXAS INSTRUMENTS; Database Table Name: v_bt_all; CHAMP10: -65°C/+150°C; CHAMP9: -40°C/+125°C; SS_FAMILLE: CI_DRIVER; REV_DATE: 04/05/2020 00:00:54; REF_FAB: 2N7001TDCKR; Library Name: SVNDatabase_BaseTechnique.SVNDbLib; ID_RnD: 67U00126; HelpURL: http://bt_rnd/ds/06_ci/TI/2n7001t.pdf; ComponentLink2Description: Manufacturer Website; ComponentLink1Description: Manufacturer Datasheet; Component Kind: Standard; CHAMP6: -0.5V/+4.2V; CHAMP5: BUFFER; CHAMP2: SC70; CHAMP1: CMS; ACTIF: ACTIF
U17 = 67U00125: CI_DRIVER CMS SO16W USB +3.1V/+5.5V Isolation 5kV 12Mbps; REV_NOTE: Migration vers t_bt; REV_AUTHOR: lclavier; LEVEL: 1; FAMILLE: CIRCUITS_INTEGRES; FAB: ANALOG DEVICES; Database Table Name: v_bt_all; CHAMP10: -65°C/+150°C; CHAMP9: -40°C/+105°C; SS_FAMILLE: CI_DRIVER; REV_DATE: 27/04/2020 15:30:04; REF_FAB: ADUM4160BRIZ; ID_RnD: 67U00125; HelpURL: http://bt_rnd/ds/06_ci/ad/ADuM4160.pdf; ComponentLink2Description: Manufacturer Website; ComponentLink1Description: Manufacturer Datasheet; Component Kind: Standard; CHAMP8: 12Mbps; CHAMP7: Isolation 5kV; CHAMP6: +3.1V/+5.5V; CHAMP5: USB; CHAMP2: SO16W; CHAMP1: CMS; ACTIF: ACTIF; Library Name: SVNDatabase_BaseTechnique.SVNDbLib
U18 = 67U00086: CI_DRIVER CMS SSOP16  UART/USB +2.97V/+5.5V; REV_NOTE: Maj Footprint Ref; REV_AUTHOR: jcaille; QUALIF: None; LEVEL: 1; FAMILLE: CIRCUITS_INTEGRES; FAB: FTDI; Database Table Name: v_bt_all; CHAMP10: -65°C/+150°C; CHAMP9: -40°C/+85°C; SS_FAMILLE: CI_DRIVER; REV_DATE: 12/02/2020 16:05:14; REF_FAB: FT230XS; ID_RnD: 67U00086; HelpURL: http://bt_rnd/ds/06_ci/ftdi/DS_FT230X.pdf; ComponentLink2Description: Manufacturer Website; ComponentLink1URL: https://www.ftdichip.com/Support/Documents/DataSheets/ICs/DS_FT230X.pdf; ComponentLink1Description: Manufacturer Datasheet; Component Kind: Standard; CHAMP6: +2.97V/+5.5V; CHAMP5: UART/USB; CHAMP2: SSOP16; CHAMP1: CMS; ACTIF: ACTIF; Library Name: SVNDatabase_BaseTechnique.SVNDbLib
U24 = 6FU00005: CI_MODULE CMS RN2483 LoRa UART +2.1V/+3.6V Tx:14dBm/Rx:-148dBm 0; REV_NOTE: Migration vers t_bt; REV_AUTHOR: llannuzel; LEVEL: 1; FAMILLE: CIRCUITS_INTEGRES; FAB: MICROCHIP; Database Table Name: v_bt_all; CHAMP10: -40°C/+115°C; CHAMP9: -40°C/+85°C; CHAMP8: 0; SS_FAMILLE: CI_MODULE; REV_DATE: 10/04/2020 11:59:28; REF_FAB: RN2483; ID_RnD: 6FU00005; HelpURL: http://BT_RND/ds/06_ci/MICROCHIP/MICROCHIP_RN2483.pdf; ComponentLink2URL: http://www.microchip.com; ComponentLink2Description: Manufacturer Website; ComponentLink1URL: http://ww1.microchip.com/downloads/en/DeviceDoc/50002346A.pdf; ComponentLink1Description: Manufacturer Datasheet; Component Kind: Standard; CHAMP7: Tx:14dBm/Rx:-148dBm; CHAMP6: +2.1V/+3.6V; CHAMP5: UART; CHAMP3: LoRa; CHAMP2: RN2483; CHAMP1: CMS; ACTIF: ACTIF; Library Name: SVNDatabase_BaseTechnique.SVNDbLib
